# Altium schematic sheet picoamp_v30.SchDoc
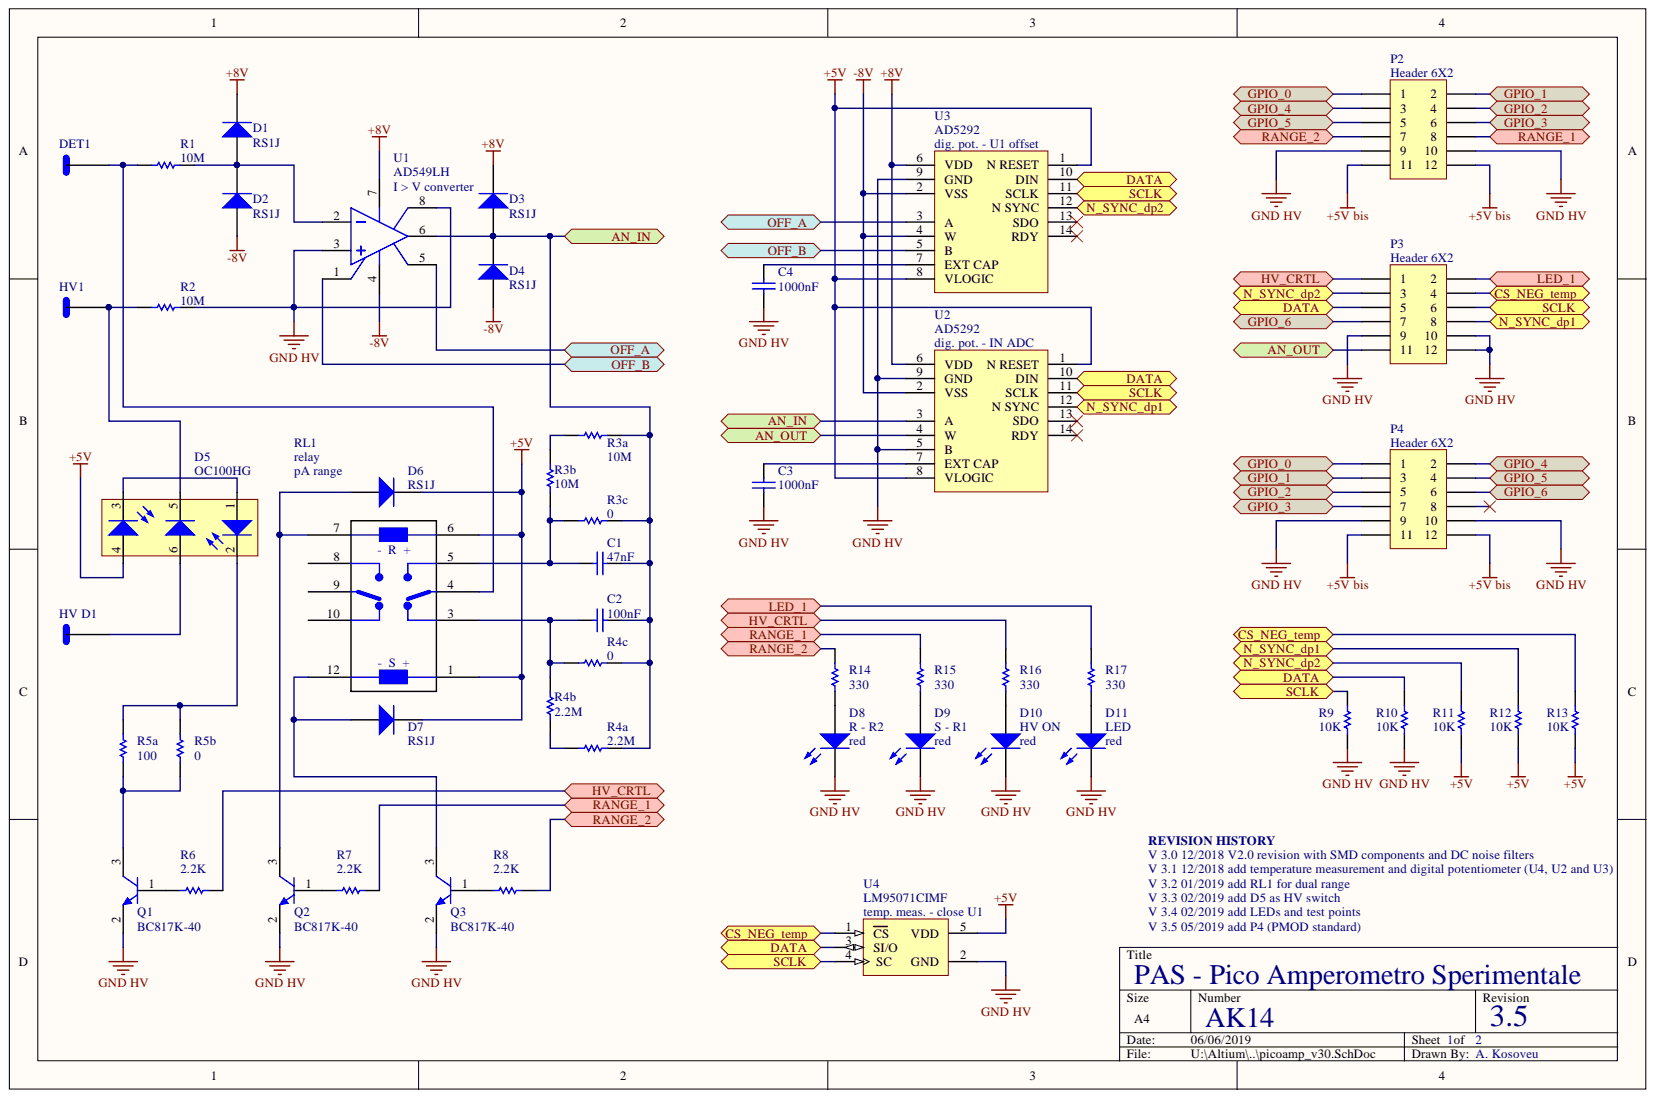
[diagram p0: picoamp_v30.SchDoc]

## Parts
C1  value 47nF  [C 1812 (4532)]  (hidden comment: Cap)
C2  value 100nF  [C 1812 (4532)]  (hidden comment: Cap)
C3  value 1000nF  [C 1206 (3216)]  (hidden comment: Cap)
C4  value 1000nF  [C 1206 (3216)]  (hidden comment: Cap)
D1  RS1J  [SMA]
D2  RS1J  [SMA]
D3  RS1J  [SMA]
D4  RS1J  [SMA]
D5  OC100HG  [OC100HG]
D6  RS1J  [SMA]
D7  RS1J  [SMA]
D8  R - R2  colore: red  [3.2X1.6X1.1]
D9  S - R1  colore: red  [3.2X1.6X1.1]
D10  HV ON  colore: red  [3.2X1.6X1.1]
D11  LED  colore: red  [3.2X1.6X1.1]
DET1  HV PAD  [TP]  (value not drawn)
HV1  HV PAD  [TP]  (value not drawn)
HV_D1  HV PAD  [TP]  (value not drawn)
P2  Header 6X2  [HDR2X6]
P3  Header 6X2  [HDR2X6]
P4  Header 6X2  [HDR2X6]
Q1  BC817K-40  [ONSC-SOT-23-3-318-08_V]
Q2  BC817K-40  [ONSC-SOT-23-3-318-08_V]
Q3  BC817K-40  [ONSC-SOT-23-3-318-08_V]
R1  value 10M  [R 2512 (6432)]  (hidden comment: Res)
R2  value 10M  [R 2512 (6432)]  (hidden comment: Res)
R3a  value 10M  [R 2512 (6432)]  (hidden comment: Res)
R3b  value 10M  [R 2512 (6432)]  (hidden comment: Res)
R3c  value 0  [R 2512 (6432)]  (hidden comment: Res)
R4a  value 2.2M  [R 2512 (6432)]  (hidden comment: Res)
R4b  value 2.2M  [R 2512 (6432)]  (hidden comment: Res)
R4c  value 0  [R 2512 (6432)]  (hidden comment: Res)
R5a  value 100  [R 1206 [3216]]  (hidden comment: Res)
R5b  value 0  [R 1206 [3216]]  (hidden comment: Res)
R6  value 2.2K  [R 1206 [3216]]  (hidden comment: Res)
R7  value 2.2K  [R 1206 [3216]]  (hidden comment: Res)
R8  value 2.2K  [R 1206 [3216]]  (hidden comment: Res)
R9  value 10K  [R 1206 [3216]]  (hidden comment: Res)
R10  value 10K  [R 1206 [3216]]  (hidden comment: Res)
R11  value 10K  [R 1206 [3216]]  (hidden comment: Res)
R12  value 10K  [R 1206 [3216]]  (hidden comment: Res)
R13  value 10K  [R 1206 [3216]]  (hidden comment: Res)
R14  value 330  [R 1206 [3216]]  (hidden comment: Res)
R15  value 330  [R 1206 [3216]]  (hidden comment: Res)
R16  value 330  [R 1206 [3216]]  (hidden comment: Res)
R17  value 330  [R 1206 [3216]]  (hidden comment: Res)
RL1  relay  [G6SK-2F]
U1  AD549LH  xomm: I > V converter  [AD549]
U2  AD5292  note: dig. pot. - IN ADC  [TSOOP_14]
U3  AD5292  note: dig. pot. - U1 offset  [TSOOP_14]
U4  LM95071CIMF  note: temp. meas. - close U1  [MF05A_N]

## Ports
AN_IN, AN_OUT, CS_NEG_TEMP, DATA, GPIO_0, GPIO_1, GPIO_2, GPIO_3, GPIO_4, GPIO_5, GPIO_6, HV_CRTL, LED_1, N_SYNC_DP1, N_SYNC_DP2, OFF_A, OFF_B, RANGE_1, RANGE_2, SCLK

## Nets
+5V: D5.4 D6.2 D7.2 R11.1 R12.1 R13.1 RL1.1 RL1.6 U2.1(N_RESET) U2.8(VLOGIC) U3.1(N_RESET) U3.8(VLOGIC) U4.5(VDD)
+5V BIS: P2.11 P2.12 P4.11 P4.12
+8V: D1.2 D3.2 U1.7 U2.6(VDD) U3.6(VDD)
-8V: D2.1 D4.1 U1.4 U2.2(VSS) U3.2(VSS) U3.4(W)
GND HV: C3.1 C4.1 D8.2 D9.2 D10.2 D11.2 P2.9 P2.10 P3.9 P3.10 P3.12 P4.9 P4.10 Q1.2 Q2.2 Q3.2 R2.1 R9.1 R10.1 U1.3 U1.8 U2.5(B) U2.9(GND) U3.9(GND) U4.2(GND)
AN_IN: C1.1 C2.1 D3.1 D4.2 R3a.2 R3c.1 R4a.2 R4c.1 U1.6 U2.3(A) ; port AN_IN
AN_OUT: P3.11 U2.4(W) ; port AN_OUT
DATA: P3.5 R10.2 U2.10(DIN) U3.10(DIN) U4.3(SI/O) ; port DATA
GPIO_0: P2.1 P4.1 ; port GPIO_0
GPIO_1: P2.2 P4.3 ; port GPIO_1
GPIO_2: P2.4 P4.5 ; port GPIO_2
GPIO_3: P2.6 P4.7 ; port GPIO_3
GPIO_4: P2.3 P4.2 ; port GPIO_4
GPIO_5: P2.5 P4.4 ; port GPIO_5
GPIO_6: P3.7 P4.6 ; port GPIO_6
HV_CRTL: P3.1 R6.1 R16.2 ; port HV_CRTL
LED_1: P3.2 R17.2 ; port LED_1
OFF_A: U1.5 U3.3(A) ; port OFF_A
OFF_B: U1.1 U3.5(B) ; port OFF_B
RANGE_1: P2.8 R7.1 R15.2 ; port RANGE_1
RANGE_2: P2.7 R8.1 R14.2 ; port RANGE_2
SCLK: P3.6 R9.2 U2.11(SCLK) U3.11(SCLK) U4.4(SC) ; port SCLK
NetC1_2: C1.2 R3b.2 R3c.2 RL1.5
NetC2_2: C2.2 R4b.1 R4c.2 RL1.3
NetC3_2: C3.2 U2.7(EXT_CAP)
NetC4_2: C4.2 U3.7(EXT_CAP)
NetD1_1: D1.1 D2.2 R1.1 U1.2
NetD5_1: D5.1 D5.3
NetD5_2: D5.2 R5a.2 R5b.2
NetD5_5: D5.5 HV1.1 R2.2
NetD5_6: D5.6 HV_D1.1
NetD6_1: D6.1 Q2.3 RL1.7
NetD7_1: D7.1 Q3.3 RL1.12
NetD8_1: D8.1 R14.1
NetD9_1: D9.1 R15.1
NetD10_1: D10.1 R16.1
NetD11_1: D11.1 R17.1
NetDET1_1: DET1.1 R1.2 RL1.4
NetP3_3: P3.3 R11.2 U3.12(N_SYNC) ; port N_SYNC_DP2
NetP3_4: P3.4 R13.2 U4.1(CS) ; port CS_NEG_TEMP
NetP3_8: P3.8 R12.2 U2.12(N_SYNC) ; port N_SYNC_DP1
NetQ1_1: Q1.1 R6.2
NetQ1_3: Q1.3 R5a.1 R5b.1
NetQ2_1: Q2.1 R7.2
NetQ3_1: Q3.1 R8.2
NetR3a_1: R3a.1 R3b.1
NetR4a_1: R4a.1 R4b.2

Not connected: P4 8; RL1 8 9 10; U2 13(SDO) 14(RDY); U3 13(SDO) 14(RDY)

## Library data of the parts
C1-C4 = Cap: Capacitor; Component Kind: Standard; LatestRevisionDate: 17-Jul-2002; LatestRevisionNote: Re-released for DXP Platform.; PackageDescription: Radial Cap, Thru-Hole; 2 Leads; 0.3 in Pin Spacing; PackageReference: RAD-0.3; Published: 8-Jun-2000; Signal Integrity: Cap; Simulation: CAP; Library Name: picoamp_v30.SchLib; Publisher: Altium Limited
D1-D4 D6 D7 = Diode: Default Diode; Code_JEDEC: DO-214-AB; Component Kind: Standard; LatestRevisionDate: 17-Jul-2002; LatestRevisionNote: Re-released for DXP Platform.; PackageDescription: DO-214-AB/SMC; 2 C-Bend Leads; Body 7.9 x 5.9 mm, inc. leads (LxW); PackageReference: SMC; PackageVersion: Sep-1996; Published: 8-Jun-2000; Signal Integrity: Diode; Simulation: DIODE; Library Name: picoamp_v30.SchLib; Publisher: Altium Limited
D8-D11 = LED2: Typical RED, GREEN, YELLOW, AMBER GaAs LED; Component Kind: Standard; LatestRevisionDate: 17-Jul-2002; LatestRevisionNote: Re-released for DXP Platform.; PackageDescription: SM LED; 2 Flat Leads; PackageReference: 3.2X1.6X1.1; PackageVersion: Feb-2002; Published: 8-Jun-2000; Simulation: LED2; Library Name: picoamp_v30.SchLib; Publisher: Altium Limited
P2-P4 = Header 6X2: Header, 6-Pin, Dual row; Component Kind: Standard; LatestRevisionDate: 17-Jul-2002; LatestRevisionNote: Re-released for DXP Platform.; Signal Integrity: Connector; Library Name: picoamp_v30.SchLib; Publisher: Altium Limited
Q1-Q3 = BC817-40LT1: General Purpose Transistor, NPN Silicon, 3-Pin SOT-23, Tape and Reel; Manufacturer: On Semiconductor; fT Min (MHz): 100; IC Continuous (A): 0.5; Component Kind: Standard; ComponentLink1Description: Manufacturer URL; ComponentLink1URL: http://www.onsemi.com/; ComponentLink2Description: Datasheet; ComponentLink2URL: http://www.onsemi.com/pub_link/Collateral/BC817-16LT1-D.PDF; ComponentLink3Description: Package Specification; ComponentLink3URL: http://www.onsemi.cn/pub_link/Collateral/318-08.PDF; DatasheetVersion: Rev. 12; Library Name: ON Semi General Purpose Transistors.IntLib; Mounting Technology: Surface Mount; PackageDescription: 3-Pin Small Outline Transistor, Body 2.92 x 1.3 mm, Pitch 0.95 mm; PackageReference: SOT-23-3-318-08; PackageVersion: Rev. AP, 11/2009; Packing: Tape and Reel; PartNumber: BC817-40LT1; Polarity: NPN; RoHS: FALSE; Supplier 1: Digi-Key; Supplier Part Number 1: BC817-40LT1OSCT-ND
R1 R2 R3a R3b R3c R4a R4b R4c R5a R5b R6-R17 = Res: Resistor; Component Kind: Standard; LatestRevisionDate: 17-Jul-2002; LatestRevisionNote: Re-released for DXP Platform.; PackageDescription: Axial Device, Thru-Hole; 2 Leads; 0.5 in Pin Spacing; PackageReference: AXIAL-0.5; Published: 8-Jun-2000; Signal Integrity: Res Semi; Simulation: RES; Library Name: picoamp_v30.SchLib; Publisher: Altium Limited
RL1 = G6SK-2F: OMRON SMD signal relay; note: pA range; Component Kind: Standard; Library Name: picoamp_v30.SchLib
U1 = AD549LH: Ultra-Low Input Bias Current Operational Amplifier; Note: Guard Pin 8 connected to case; Component Kind: Standard; ComponentLink1Description: Manufacturer Link; ComponentLink1URL: http://www.analog.com/en/prod/0,,759_786_AD549%2C00.html; ComponentLink2Description: Datasheet; ComponentLink2URL: http://www.analog.com/UploadedFiles/Data_Sheets/32851842AD549_d.pdf; DatasheetVersion: REV. A; LatestRevisionDate: 17-Jul-2002; LatestRevisionNote: Re-released for DXP Platform.; PackageDescription: Can; 8 Leads; Pin Circle Dia. 5.08 mm; Lead Dia. 0.48 mm (max); PackageReference: H-08A_D; PackageVersion: 1993; Published: 8-Jun-2000; Library Name: picoamp_v30.SchLib; Publisher: Altium Limited
U4 = LM95071CIMF: SPI/MICROWIRE 13-Bit Plus Sign Temperature Sensor, 5-pin SOT-23; Manufacturer: National Semiconductor; CompType: Temp Sensor IC; High-Reliability: Y; PackageTopMarking: T18C*XTT; Component Kind: Standard; ComponentLink1Description: Manufacturer URL; ComponentLink1URL: http://www.national.com/; ComponentLink2Description: LM95071 Datasheet; ComponentLink2URL: http://www.national.com/ds/LM/LM95071.pdf; ComponentLink3Description: LM95071 Web Page; ComponentLink3URL: http://www.national.com/pf/LM/LM95071.html; ComponentLink4Description: MF05A Package Page; ComponentLink4URL: http://www.national.com/packaging/folders/mf05a.html; DatasheetVersion: Feb-2005; PackageDescription: Molded SOT-23, .115 x .063 x .040 in Body, 5 Lead, .0375 in Pitch; PackageReference: MF05A; PackageVersion: revD, Aug-2008; PartNumber: LM95071CIMF; PinCount: 5; PowerWise: Y; RoHS: N; Library Name: picoamp_v30.SchLib
D5 = OC100HG: Component Kind: Standard; Library Name: picoamp_v30.SchLib
DET1 HV1 HV_D1 = HV PAD: Component Kind: Standard; Library Name: picoamp_v30.SchLib
U2 U3 = AD5292: Component Kind: Standard; Library Name: picoamp_v30.SchLib
